# Altium schematic sheet MainBoard_PWR.SchDoc
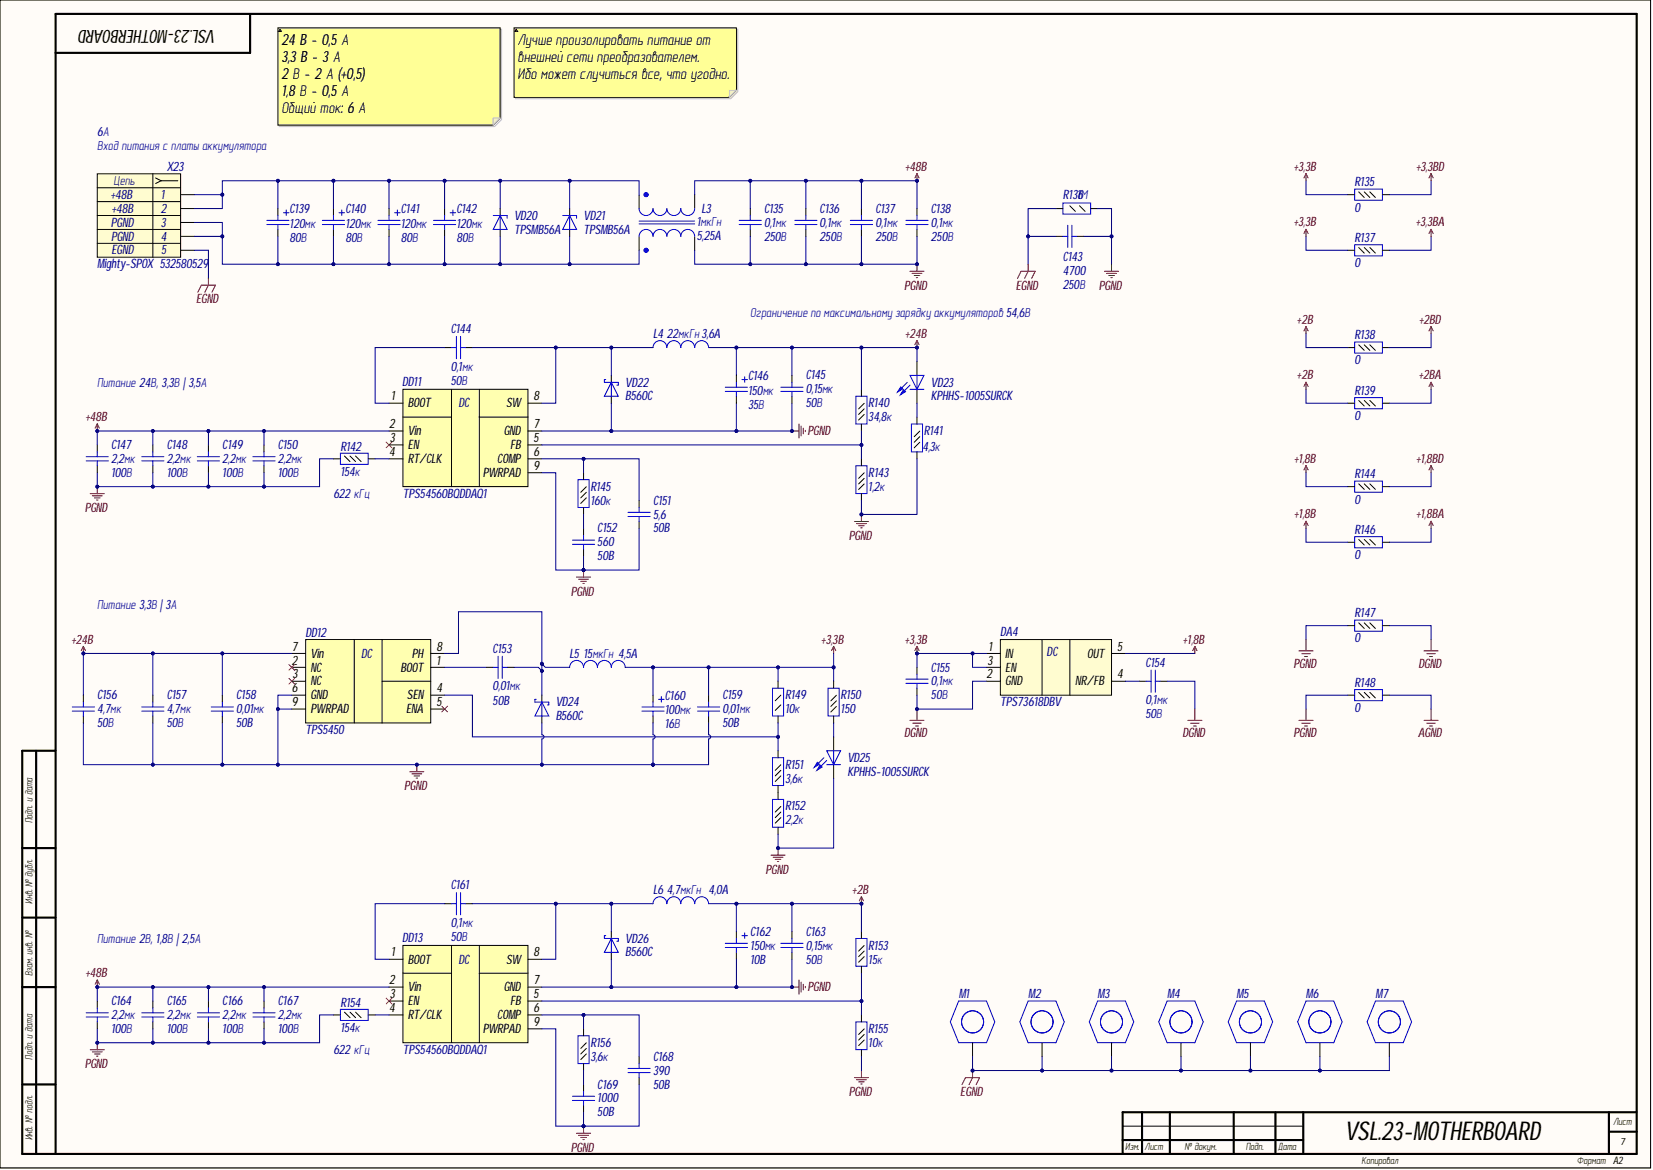
[diagram p0: MainBoard_PWR.SchDoc]

## Parts
C135  value 0,1мк  Voltage: 250В  [C1206]  (hidden comment: Capacitor)
C136  value 0,1мк  Voltage: 250В  [C1206]  (hidden comment: Capacitor)
C137  value 0,1мк  Voltage: 250В  [C1206]  (hidden comment: Capacitor)
C138  value 0,1мк  Voltage: 250В  [C1206]  (hidden comment: Capacitor)
C139  value 120мк  Voltage: 80В  [K50-35(8X20)_V]  (hidden comment: Capacitor_pol)
C140  value 120мк  Voltage: 80В  [K50-35(8X20)_V]  (hidden comment: Capacitor_pol)
C141  value 120мк  Voltage: 80В  [K50-35(8X20)_V]  (hidden comment: Capacitor_pol)
C142  value 120мк  Voltage: 80В  [K50-35(8X20)_V]  (hidden comment: Capacitor_pol)
C143  value 4700  [C0805]  (hidden comment: Capacitor)
C144  0,1мк  [C0402]
C145  0,15мк  Voltage: 50В  [C1812]
C146  value 150мк  Voltage: 35В  [Cap_SMD_radial_polar(8x10.2)]  (hidden comment: Capacitor_pol)
C147  2,2мк  Voltage: 100В  [C1812]
C148  2,2мк  Voltage: 100В  [C1812]
C149  2,2мк  Voltage: 100В  [C1812]
C150  2,2мк  Voltage: 100В  [C1812]
C151  5,6  Voltage: 50B  [C0402]
C152  560  Voltage: 50B  [C0402]
C153  0,01мк  Voltage: 50B  [C0402]
C154  value 0,1мк  Voltage: 50В  [C0402]  (hidden comment: Capacitor)
C155  value 0,1мк  Voltage: 50В  [C0402]  (hidden comment: Capacitor)
C156  4,7мк  Voltage: 50В  [C0805]
C157  4,7мк  Voltage: 50В  [C0805]
C158  0,01мк  Voltage: 50B  [C0402]
C159  0,01мк  Voltage: 50B  [C0402]
C160  value 100мк  Voltage: 16В  [TANTAL CASE D]  (hidden comment: Capacitor_pol)
C161  0,1мк  [C0402]
C162  value 150мк  Voltage: 10B  [TANTAL CASE D]  (hidden comment: Capacitor_pol)
C163  0,15мк  Voltage: 50В  [C1812]
C164  2,2мк  Voltage: 100В  [C1812]
C165  2,2мк  Voltage: 100В  [C1812]
C166  2,2мк  Voltage: 100В  [C1812]
C167  2,2мк  Voltage: 100В  [C1812]
C168  390  Voltage: 50B  [C0402]
C169  1000  Voltage: 50B  [C0402]
DA4  Part Number: TPS73618DBV  [SOT23-5]  (hidden comment: TPS736DBV)
DD11  TPS54560  Part Number: TPS54560BQDDAQ1  [SO Powerpad8]
DD12  TPS5450  [SO Powerpad8]
DD13  TPS54560  Part Number: TPS54560BQDDAQ1  [SO Powerpad8]
L3  value 1мкГн  Rated Current: 5,25A  [SRF0703]  (hidden comment: Dual-Winding Inductor)
L4  value 22мкГн  Rated Current: 3,6A  [IND_12.5x12.5]  (hidden comment: Inductor)
L5  value 15мкГн  Rated Current: 4,5A  [IND_12.5x12.5]  (hidden comment: Inductor)
L6  value 4,7мкГн  Rated Current: 4,0A  [SDR1006]  (hidden comment: Inductor)
M1  SCREW  [SCREW3]  (value not drawn)
M2  SCREW  [SCREW3]  (value not drawn)
M3  SCREW  [SCREW3]  (value not drawn)
M4  SCREW  [SCREW3]  (value not drawn)
M5  SCREW  [SCREW3]  (value not drawn)
M6  SCREW  [SCREW3]  (value not drawn)
M7  SCREW  [SCREW3]  (value not drawn)
R135  value 0  [R0402]  (hidden comment: Resistor_0,062Вт)
R136  value 1М  [R0805]  (hidden comment: Resistor_0,125Вт)
R137  value 0  [R0402]  (hidden comment: Resistor_0,062Вт)
R138  value 0  [R0402]  (hidden comment: Resistor_0,062Вт)
R139  value 0  [R0402]  (hidden comment: Resistor_0,062Вт)
R140  value 34,8к  [R0402]  (hidden comment: Resistor_0,062Вт)
R141  value 4,3к  [R0402]  (hidden comment: Resistor_0,062Вт)
R142  value 154к  [R0402]  (hidden comment: Resistor_0,062Вт)
R143  value 1,2к  [R0402]  (hidden comment: Resistor_0,062Вт)
R144  value 0  [R0402]  (hidden comment: Resistor_0,062Вт)
R145  value 160к  [R0402]  (hidden comment: Resistor_0,062Вт)
R146  value 0  [R0402]  (hidden comment: Resistor_0,062Вт)
R147  value 0  [R0402]  (hidden comment: Resistor_0,062Вт)
R148  value 0  [R0402]  (hidden comment: Resistor_0,062Вт)
R149  value 10к  [R0402]  (hidden comment: Resistor_0,125Вт)
R150  value 150  [R0402]  (hidden comment: Resistor_0,062Вт)
R151  value 3,6к  [R0402]  (hidden comment: Resistor_0,062Вт)
R152  value 2,2к  [R0402]  (hidden comment: Resistor_0,062Вт)
R153  value 15к  [R0402]  (hidden comment: Resistor_0,062Вт)
R154  value 154к  [R0402]  (hidden comment: Resistor_0,062Вт)
R155  value 10к  [R0402]  (hidden comment: Resistor_0,062Вт)
R156  value 3,6к  [R0402]  (hidden comment: Resistor_0,062Вт)
VD20  DiodeZener  [SMB]  (value not drawn)
VD21  DiodeZener  [SMB]  (value not drawn)
VD22  Part Number: B560C  [SMC]  (hidden comment: DiodeSchottky)
VD23  Part Number: KPHHS-1005SURCK  [L_0402_RED]  (hidden comment: LED)
VD24  Part Number: B560C  [SMC]  (hidden comment: DiodeSchottky)
VD25  Part Number: KPHHS-1005SURCK  [L_0402_RED]  (hidden comment: LED)
VD26  Part Number: B560C  [SMC]  (hidden comment: DiodeSchottky)
X23  Contact_1: +48B  Contact_3: PGND  Contact_5: EGND  Part Name: Mighty-SPOX  Part Number: 532580529  [MOLEX_532580529]  (hidden comment: Header_5_sct)

## Nets
+1,8B: DA4.5(OUT) R144.1 R146.1
+1,8BA: R146.2
+1,8BD: R144.2
+2B: C162.1 C163.1 L6.2 R138.1 R139.1 R153.2
+2BA: R139.2
+2BD: R138.2
+3,3B: C155.1 C159.2 C160.1 DA4.1(IN) DA4.3(EN) L5.2 R135.1 R137.1 R149.2 R150.2
+3,3BA: R137.2
+3,3BD: R135.2
+24B: C145.1 C146.1 C156.2 C157.2 C158.2 DD12.7(Vin) L4.2 R140.2 VD23.2
+48B: C135.2 C136.2 C137.2 C138.2 C147.2 C148.2 C149.2 C150.2 C164.2 C165.2 C166.2 C167.2 DD11.2(Vin) DD13.2(Vin) L3.4
AGND: R148.2
DGND: C154.2 C155.2 DA4.2(GND) R147.2
EGND: C143.2 M1.1 M2.1 M3.1 M4.1 M5.1 M6.1 M7.1 R136.2 X23.5
PGND: C135.1 C136.1 C137.1 C138.1 C143.1 C145.2 C146.2 C147.1 C148.1 C149.1 C150.1 C151.1 C152.1 C156.1 C157.1 C158.1 C159.1 C160.2 C162.2 C163.2 C164.1 C165.1 C166.1 C167.1 C168.1 C169.1 DD11.7(GND) DD11.9(PWRPAD) DD12.6(GND) DD12.9(PWRPAD) DD13.7(GND) DD13.9(PWRPAD) L3.3 R136.1 R141.1 R142.1 R143.1 R147.1 R148.1 R152.1 R154.1 R155.1 VD22.2 VD24.2 VD25.1 VD26.2
NetC139_1: C139.1 C140.1 C141.1 C142.1 L3.2 VD20.1 VD21.1 X23.1 X23.2
NetC139_2: C139.2 C140.2 C141.2 C142.2 L3.1 VD20.2 VD21.2 X23.3 X23.4
NetC144_1: C144.1 DD11.8(SW) L4.1 VD22.1
NetC144_2: C144.2 DD11.1(BOOT)
NetC151_2: C151.2 DD11.6(COMP) R145.2
NetC152_2: C152.2 R145.1
NetC153_1: C153.1 DD12.8(PH) L5.1 VD24.1
NetC153_2: C153.2 DD12.1(BOOT)
NetC154_1: C154.1 DA4.4(NR/FB)
NetC161_1: C161.1 DD13.8(SW) L6.1 VD26.1
NetC161_2: C161.2 DD13.1(BOOT)
NetC168_2: C168.2 DD13.6(COMP) R156.2
NetC169_2: C169.2 R156.1
NetDD11_4: DD11.4(RT/CLK) R142.2
NetDD11_5: DD11.5(FB) R140.1 R143.2
NetDD12_4: DD12.4(SEN) R149.1 R151.2
NetDD13_4: DD13.4(RT/CLK) R154.2
NetDD13_5: DD13.5(FB) R153.1 R155.2
NetR141_2: R141.2 VD23.1
NetR150_1: R150.1 VD25.2
NetR151_1: R151.1 R152.2

Not connected: DD11 3(EN); DD12 2(NC) 3(NC) 5(ENA); DD13 3(EN)

## Library data of the parts
C135-C138 = cap179: Конденсатор; Manufacture: Murata; Part Name: Ceramic; Part Number: GRM31CR72E104K; Database Table Name: Capacitors$; TKE: X7R; Component Kind: Standard; id: cap179; Library Name: MOP44.DbLib
C139-C142 = cap300: Конденсатор полярный; Manufacture: Panasonic; Part Name: Electrolit; Part Number: EEU-FS1K121LB; Database Table Name: Capacitors$; Ripple current: 0,81A; tga: 0.08; Zmax: 0,096Ом; Component Kind: Standard; id: cap300; Library Name: MOP44.DbLib
C143 = cap211: Конденсатор; Manufacture: Murata; Part Name: Ceramic; Part Number: GRM21AR72E472KW; Database Table Name: Capacitors$; TKE: X7R; Voltage: 250В; Component Kind: Standard; id: cap211; Library Name: MOP44.DbLib
C144 C161 = cap277: Конденсатор; Manufacture: Murata; Part Name: Ceramic; Part Number: GRM155R71H104KE14D; Database Table Name: Capacitors$; tga: 0,10%; TKE: X7R; Voltage: 50В; Component Kind: Standard; id: cap277; Library Name: MOP44.DbLib; Value: 0,1мк
C145 C163 = cap285: Конденсатор; Manufacture: TDK; Part Name: Ceramic; Part Number: C4532C0G1H154J250KA; Database Table Name: Capacitors$; TKE: NP0; Component Kind: Standard; id: cap285; Library Name: MOP44.DbLib; Value: 0,15мк
C146 = cap304: Конденсатор полярный; Manufacture: Panasonic; Part Name: Electrolit; Part Number: EEH-ZE1V151V; Database Table Name: Capacitors$; ESR: 27мОм; Component Kind: Standard; id: cap304; Library Name: MOP44.DbLib
C147-C150 C164-C167 = cap293: Конденсатор; Manufacture: TDK; Part Name: MLCC; Part Number: C4532X7R2A225M; Database Table Name: Capacitors$; tga: 0,10%; TKE: X7R; Component Kind: Standard; id: cap293; Library Name: MOP44.DbLib; Value: 2,2мк
C151 = cap4: Конденсатор; Manufacture: Murata; Part Name: Ceramic; Part Number: GRM1555C1H5R6C; Database Table Name: Capacitors$; TKE: NP0; Component Kind: Standard; id: cap4; Library Name: MOP44.DbLib; Value: 5,6
C152 = cap25: Конденсатор; Manufacture: Murata; Part Name: Ceramic; Part Number: GRM1555C1H561J; Database Table Name: Capacitors$; TKE: NP0; Component Kind: Standard; id: cap25; Library Name: MOP44.DbLib; Value: 560
C153 C158 C159 = cap42: Конденсатор; Manufacture: Murata; Part Name: Ceramic; Part Number: GRM155R71H103K; Database Table Name: Capacitors$; TKE: X7R; Component Kind: Standard; id: cap42; Library Name: MOP44.DbLib; Value: 0,01мк
C154 C155 = cap277: Конденсатор; Manufacture: Murata; Part Name: Ceramic; Part Number: GRM155R71H104KE14D; Database Table Name: Capacitors$; tga: 0,10%; TKE: X7R; Component Kind: Standard; id: cap277; Library Name: MOP44.DbLib
C156 C157 = cap224: Конденсатор; Manufacture: Murata; Part Name: Ceramic; Part Number: GRM21BZ71H475KE15L; Database Table Name: Capacitors$; TKE: X7R; Component Kind: Standard; id: cap224; Library Name: MOP44.DbLib; Value: 4,7мк
C160 = cap210: Конденсатор полярный; Manufacture: Vishay; Part Name: Tantal; Part Number: 293D107X9016D2TE3; Database Table Name: Capacitors$; Component Kind: Standard; id: cap210; Library Name: MOP44.DbLib
C162 = cap302: Конденсатор полярный; Part Name: Tantal; Part Number: TPSD157K010R0050; Database Table Name: Capacitors$; ESR: 50мОм; Component Kind: Standard; id: cap302; Library Name: MOP44.DbLib
C168 = cap23: Конденсатор; Manufacture: Murata; Part Name: Ceramic; Part Number: GRM1555C1H391J; Database Table Name: Capacitors$; TKE: NP0; Component Kind: Standard; id: cap23; Library Name: MOP44.DbLib; Value: 390
C169 = cap28: Конденсатор; Manufacture: Murata; Part Name: Ceramic; Part Number: GRM1555C1H102J; Database Table Name: Capacitors$; TKE: NP0; Component Kind: Standard; id: cap28; Library Name: MOP44.DbLib; Value: 1000
DA4 = pwr118: 400-mA Low-Dropout Regulator with Reverse Current  Protection; Manufacturer: Texas Instruments; Current: 400mA; Database Table Name: PowerTransducer$; Dropout Voltage: 75mB; Input Voltage: 1,7-5,5В; Output Voltage: 1,8B; Component Kind: Standard; id: pwr118; Library Name: MOP44.DbLib; Part Name: Low-Dropout Regulator with Reverse Current Protection; Type: Linear
DD11 DD13 = pwr127: 5-A, Wide Input Range, Step-Down Converter; Manufacturer: Texas Instruments; Part Name: Step Down DC-DC Converter with Eco-mode; Current: 5A; Database Table Name: PowerTransducer$; Frequency: 100 kHz-25 MHz; Input Voltage: 4,5-60В; Output Voltage: 0,8B-58,8B; Component Kind: Standard; id: pwr127; Library Name: MOP44.DbLib; Type: Impulse
DD12 = pwr12: 5-A, Wide Input Range, Step-Down Converter; Manufacturer: Texas Instruments; Current: 5A; Database Table Name: PowerTransducer$; Input Voltage: 5,5 - 36B; Output Voltage: Adj; Component Kind: Standard; id: pwr12; Library Name: MOP44.DbLib; Part Name: Step-Down Converter; Part Number: TPS5450; Type: Impulse
L3 = ind10: Синфазный дроссель; Manufacture: Bourns; Part Name: Dual-Winding Shielded Power Inductors; Part Number: SRF0703-1R0M; Database Table Name: Inductor$; Resistance: 0,0135Ohm; Saturation Current: 7,97A; Component Kind: Standard; id: ind10; Library Name: MOP44.DbLib
L4 = ind79: EMI Suppression Filter; Manufacture: Epcos; Part Name: SMD Power Inductors; Part Number: B82477G4223M000; Database Table Name: Inductor$; Resistance: 0,038Ohm; Saturation Current: 4,25A; Component Kind: Standard; id: ind79; Library Name: MOP44.DbLib
L5 = ind78: EMI Suppression Filter; Manufacture: Epcos; Part Name: SMD Power Inductors; Part Number: B82477G4153M000; Database Table Name: Inductor$; Resistance: 0,027Ohm; Saturation Current: 5,25A; Component Kind: Standard; id: ind78; Library Name: MOP44.DbLib
L6 = ind95: EMI Suppression Filter; Manufacture: Bourns; Part Name: SMD Power Inductors; Part Number: SDR1006-4R7ML; Database Table Name: Inductor$; Resistance: 0,036Ohm; Saturation Current: 7,3A; Component Kind: Standard; id: ind95; Library Name: MOP44.DbLib
M1-M7 = connect89: Part Name: Винт М3 с заземлением; Database Table Name: Connector$; Component Kind: Standard; id: connect89; Library Name: MOP44.DbLib
R135 R137-R139 R144 R146-R148 = res390: Резистор; Manufacture: Yageo; Part Number: RC0603FR-070RL; Accuracy: 0,01; Database Table Name: Resistor$; Component Kind: Standard; id: res390; Library Name: MOP44.DbLib; Part Name: Resistor; Power: 0,062Вт
R136 = res149: Резистор; Manufacture: Yageo; Part Number: RC0805FR-071ML; Accuracy: 0,01; Database Table Name: Resistor$; Component Kind: Standard; id: res149; Library Name: MOP44.DbLib; Part Name: Resistor; Power: 0,125Вт
R140 = res1193: Резистор; Manufacture: Yageo; Part Number: RC0402FR-0734K8L; Accuracy: 0,01; Database Table Name: Resistor$; Component Kind: Standard; id: res1193; Library Name: MOP44.DbLib; Part Name: Resistor; Power: 0,062Вт
R141 = res285: Резистор; Manufacture: Yageo; Part Number: RC0402FR-074K3; Accuracy: 0,01; Database Table Name: Resistor$; Component Kind: Standard; id: res285; Library Name: MOP44.DbLib; Part Name: Resistor; Power: 0,062Вт
R142 R154 = res1211: Резистор; Manufacture: Yageo; Part Number: RC0402FR-07154KL; Accuracy: 0,01; Database Table Name: Resistor$; Component Kind: Standard; id: res1211; Library Name: MOP44.DbLib; Part Name: Resistor; Power: 0,062Вт
R143 = res272: Резистор; Manufacture: Yageo; Part Number: RC0402FR-071K2; Accuracy: 0,01; Database Table Name: Resistor$; Component Kind: Standard; id: res272; Library Name: MOP44.DbLib; Part Name: Resistor; Power: 0,062Вт
R145 = res323: Резистор; Manufacture: Yageo; Part Number: RC0402FR-07160KL; Accuracy: 0,01; Database Table Name: Resistor$; Component Kind: Standard; id: res323; Library Name: MOP44.DbLib; Part Name: Resistor; Power: 0,062Вт
R149 = res97: Резистор; Manufacture: Yageo; Part Number: RC0805FR-0710KL; Accuracy: 0,01; Database Table Name: Resistor$; Component Kind: Standard; id: res97; Library Name: MOP44.DbLib; Part Name: Resistor; Power: 0,125Вт
R150 = res250: Резистор; Manufacture: Yageo; Part Number: RC0402FR-07150RL; Accuracy: 0,01; Database Table Name: Resistor$; Component Kind: Standard; id: res250; Library Name: MOP44.DbLib; Part Name: Resistor; Power: 0,062Вт
R151 R156 = res283: Резистор; Manufacture: Yageo; Part Number: RC0402FR-072K6; Accuracy: 0,01; Database Table Name: Resistor$; Component Kind: Standard; id: res283; Library Name: MOP44.DbLib; Part Name: Resistor; Power: 0,062Вт
R152 = res278: Резистор; Manufacture: Yageo; Part Number: RC0402FR-072K2; Accuracy: 0,01; Database Table Name: Resistor$; Component Kind: Standard; id: res278; Library Name: MOP44.DbLib; Part Name: Resistor; Power: 0,062Вт
R153 = res491: Резистор; Manufacture: Yageo; Part Number: RC0603FR-0715KL; Accuracy: 0,01; Database Table Name: Resistor$; Component Kind: Standard; id: res491; Library Name: MOP44.DbLib; Part Name: Resistor; Power: 0,062Вт
R155 = res487: Резистор; Manufacture: Yageo; Part Number: RC0603FR-0710KL; Accuracy: 0,01; Database Table Name: Resistor$; Component Kind: Standard; id: res487; Library Name: MOP44.DbLib; Part Name: Resistor; Power: 0,062Вт
VD20 VD21 = TPSMB56A: Cтабилитрон (лавинный выпрямительный диод) односторонний; Manufacture: Littelfuse; Part Name: TVS diode Unidirectional; Breakdown Voltage: 58,8B; Clamping voltage: 77B; Database Table Name: TVSdiode$; id: tvs285; Leakage Current: 8uA; Number of diodes: 1; Peak Pulse Power: 600W; Stand-off Voltage: 56B; Component Kind: Standard; Library Name: MOP44.DbLib; Part Number: TPSMB56A
VD22 VD24 VD26 = diode32: Диод Шоттки; Manufacture: Diodes Incorporated; Part Name: SCHOTTKY BARRIER RECTIFIER; Breakdown Voltage: 60B; Capacitance: 300pF; Database Table Name: Diode$; Forward Current: 5A; Forward Voltage: 0,7B; Leakage current: 20mA; Number of Channels: 1; Component Kind: Standard; id: diode32; Library Name: MOP44.DbLib; Max Reverse Voltage: 60B; Temp MAX: +150; Temp MIN: -55
VD23 VD25 = diode28: Светодиод; Part Name: LED red; Database Table Name: Diode$; Component Kind: Standard; id: diode28; Library Name: MOP44.DbLib
X23 = connect106: Разъем - 5 конт. розетка; Manufacture: Molex; Database Table Name: Connector$; Etch: 3.5mm; Component Kind: Standard; Contact_2: +48B; Contact_4: PGND; id: connect106; Library Name: MOP44.DbLib
